# Altium schematic sheet sense2.SchDoc
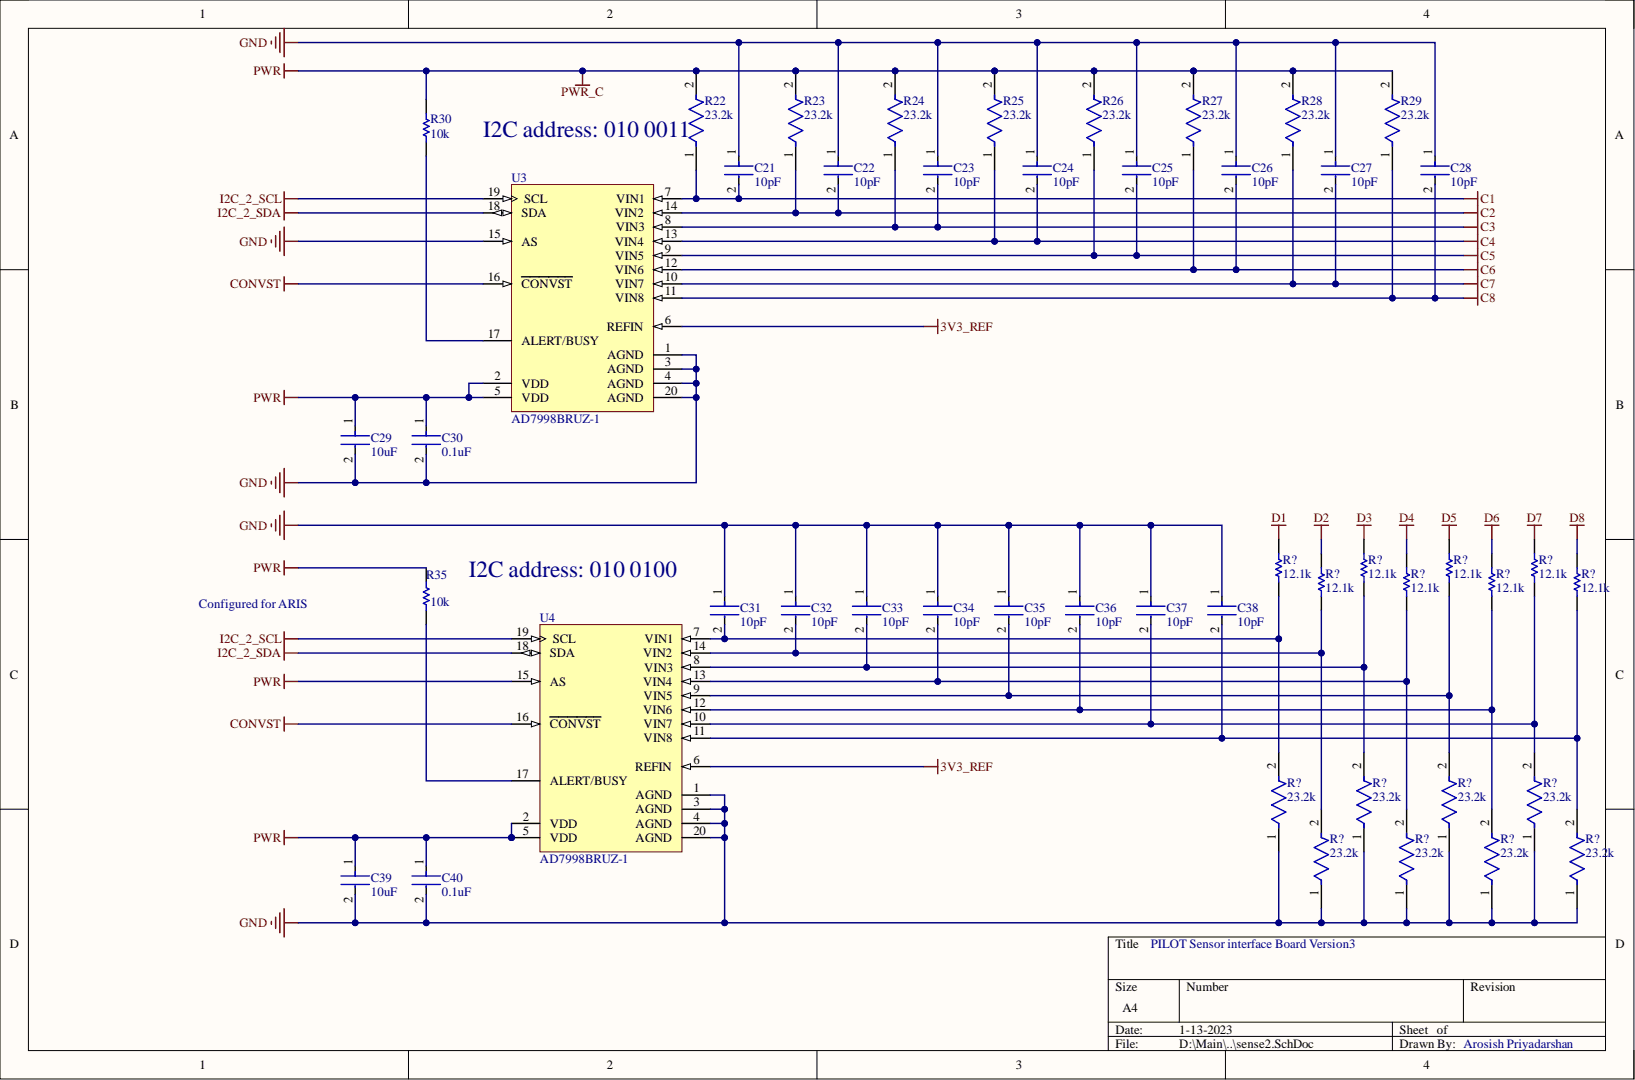
[diagram p0: sense2.SchDoc]

## Parts
C21  10pF  [FP-C0603C-CF-MFG]
C22  10pF  [FP-C0603C-CF-MFG]
C23  10pF  [FP-C0603C-CF-MFG]
C24  10pF  [FP-C0603C-CF-MFG]
C25  10pF  [FP-C0603C-CF-MFG]
C26  10pF  [FP-C0603C-CF-MFG]
C27  10pF  [FP-C0603C-CF-MFG]
C28  10pF  [FP-C0603C-CF-MFG]
C29  10uF  [FP-GCM21B-0_2-e0_2_0_7-IPC_C]
C30  0.1uF  [FP-C0603C104K5RECAUTO-MFG]
C31  10pF  [FP-C0603C-CF-MFG]
C32  10pF  [FP-C0603C-CF-MFG]
C33  10pF  [FP-C0603C-CF-MFG]
C34  10pF  [FP-C0603C-CF-MFG]
C35  10pF  [FP-C0603C-CF-MFG]
C36  10pF  [FP-C0603C-CF-MFG]
C37  10pF  [FP-C0603C-CF-MFG]
C38  10pF  [FP-C0603C-CF-MFG]
C39  10uF  [FP-GCM21B-0_2-e0_2_0_7-IPC_C]
C40  0.1uF  [FP-C0603C104K5RECAUTO-MFG]
R22  23.2k  [FP-RT0805-MFG]
R23  23.2k  [FP-RT0805-MFG]
R24  23.2k  [FP-RT0805-MFG]
R25  23.2k  [FP-RT0805-MFG]
R26  23.2k  [FP-RT0805-MFG]
R27  23.2k  [FP-RT0805-MFG]
R28  23.2k  [FP-RT0805-MFG]
R29  23.2k  [FP-RT0805-MFG]
R30  10k  [RESC2013X55X40ML15T20]
R35  10k  [RESC2013X55X40ML15T20]
R?  23.2k  [FP-RT0805-MFG]
R?  23.2k  [FP-RT0805-MFG]
R?  23.2k  [FP-RT0805-MFG]
R?  23.2k  [FP-RT0805-MFG]
R?  23.2k  [FP-RT0805-MFG]
R?  23.2k  [FP-RT0805-MFG]
R?  23.2k  [FP-RT0805-MFG]
R?  23.2k  [FP-RT0805-MFG]
R?  12.1k  [RESC2013X60X40LL20T25]
R?  12.1k  [RESC2013X60X40LL20T25]
R?  12.1k  [RESC2013X60X40LL20T25]
R?  12.1k  [RESC2013X60X40LL20T25]
R?  12.1k  [RESC2013X60X40LL20T25]
R?  12.1k  [RESC2013X60X40LL20T25]
R?  12.1k  [RESC2013X60X40LL20T25]
R?  12.1k  [RESC2013X60X40LL20T25]
U3  AD7998BRUZ-1  [RU-20_N]
U4  AD7998BRUZ-1  [RU-20_N]

## Nets
3V3_REF: U3.6(REFIN) U4.6(REFIN)
C1: C21.2 R22.1 U3.7(VIN1)
C2: C22.2 R23.1 U3.14(VIN2)
C3: C23.2 R24.1 U3.8(VIN3)
C4: C24.2 R25.1 U3.13(VIN4)
C5: C25.2 R26.1 U3.9(VIN5)
C6: C26.2 R27.1 U3.12(VIN6)
C7: C27.2 R28.1 U3.10(VIN7)
C8: C28.2 R29.1 U3.11(VIN8)
CONVST: U3.16(CONVST) U4.16(CONVST)
D1: R?.2
D2: R?.2
D3: R?.2
D4: R?.2
D5: R?.2
D6: R?.2
D7: R?.2
D8: R?.2
GND: C21.1 C22.1 C23.1 C24.1 C25.1 C26.1 C27.1 C28.1 C29.2 C30.2 C31.1 C32.1 C33.1 C34.1 C35.1 C36.1 C37.1 C38.1 C39.2 C40.2 R?.1 U3.1(AGND) U3.3(AGND) U3.4(AGND) U3.15(AS) U3.20(AGND) U4.1(AGND) U4.3(AGND) U4.4(AGND) U4.20(AGND)
I2C_2_SCL: U3.19(SCL) U4.19(SCL)
I2C_2_SDA: U3.18(SDA) U4.18(SDA)
PWR: C29.1 C30.1 C39.1 C40.1 R22.2 R23.2 R24.2 R25.2 R26.2 R27.2 R28.2 R29.2 R30.2 R35.2 U3.2(VDD) U3.5(VDD) U4.2(VDD) U4.5(VDD) U4.15(AS)
NetC31_2: C31.2 R?.1 R?.2 U4.7(VIN1)
NetC32_2: C32.2 R?.1 R?.2 U4.14(VIN2)
NetC33_2: C33.2 R?.1 R?.2 U4.8(VIN3)
NetC34_2: C34.2 R?.1 R?.2 U4.13(VIN4)
NetC35_2: C35.2 R?.1 R?.2 U4.9(VIN5)
NetC36_2: C36.2 R?.1 R?.2 U4.12(VIN6)
NetC37_2: C37.2 R?.1 R?.2 U4.10(VIN7)
NetC38_2: C38.2 R?.1 R?.2 U4.11(VIN8)
NetR30_1: R30.1 U3.17(ALERT/BUSY)
NetR35_1: R35.1 U4.17(ALERT/BUSY)

## Library data of the parts
C21-C28 C31-C38 = CMP-03020-008759-1: C0603 10 pF C0G 30ppm/°C 5.00% 25 V; Manufacturer: KEMET; Manufacturer Part Number: C0603C100J3GACAUTO; Automotive Grade: Grade 1; Material: Ceramic; Tolerance: 0.05; Voltage Rating: 25 V; Automotive: Yes; Capacitance: 10 pF; Category: Cap; Component Kind: Standard; ComponentLink2Description: Datasheet; ComponentLink2URL: https://api.kemet.com/component-edge/download/datasheet/C0603C100J5GACAUTO.pdf; Device Class L1: Passive Components; Device Class L2: Capacitors; Device Class L3: Ceramic Capacitors; Height: 0.87mm; Lead Free: Yes; Library Name: Altium Content Vault; Octopart: https://octopart.com/c0603c100j3gacauto-kemet-72007099; Package: C0603C-CF; RoHS: TRUE; Temperature: -55°C to +125°C; Temperature Characteristic: C0G; Temperature Coefficient: 30ppm/°C; Temperature Range High: +125°C; Temperature Range Low: -55°C; Value: 10 pF
C29 C39 = CMP-06035-029187-1: Ceramic Capacitor for Automotive 10uF ±10% 16VDC X7S 0805 Embossed T/R; Component Kind: Standard; Library Name: Altium Content Vault
C30 C40 = CMP-03020-034675-1: CAP CER 0.10UF 50V X7R 0603; Capacitance: 100nF; Interface: SPI; Number of A/D Converters: 1; Number of Bits: 16; Sampling Rate: 4000S/s; Tolerance: 10%; Case Code (Imperial): 0603; Case Code (Metric): 1608; Case/Package: 0603; Component Kind: Standard; Library Name: Altium Content Vault; Max Operating Temperature: 125°C; Min Operating Temperature: -55°C; Mount: Surface Mount; Packaging: Tape and Reel; Ratings: AEC-Q200; RoHS Compliant: Yes; Voltage Rating: 50V
R22-R29 R? R? R? R? R? R? R? R? = CMP-03412-003095-1: RES SMD 23.2K OHM 0.1% 1/8W 0805; Manufacturer: Yageo; Manufacturer Part Number: RT0805BRD0723K2L; Power Rating: 0.125W; Resistance: 23.2KΩ; Temperature Coefficient: 25 ppm/°C; Tolerance: 0.0025; Value: 23.2KΩ; Voltage Rating: 150V; Automotive: No; Category: Res; Component Kind: Standard; ComponentLink2Description: Datasheet; ComponentLink2URL: http://www.yageo.com/documents/recent/PYu-RT_1-to-0.01_RoHS_L_9.pdf; Composition: Thin Film; Device Class L1: Passive Components; Device Class L2: Resistors; Device Class L3: Chip SMD Resistors; Digikey Description: RES SMD 23.2K OHM 0.1% 1/8W 0805; Footprint Url: http://www.yageo.com/exep/pages/download/literatures/PYu-R_Mount_10.pdf; Height: 0.6mm; Ipc Land Pattern Name: RESC200125X50; Lead Free: Yes; Library Name: Altium Content Vault; Mouser Description: Thin Film Resistors - SMD 1/8W 23.2K ohm .1% 25ppm; Octopart: https://octopart.com/rt0805brd0723k2l-yageo-1375179; Package: RT0805; RoHS: TRUE; Series: RT; Temperature: -55°C to +155°C; Temperature Range High: +155°C; Temperature Range Low: -55°C
R30 R35 = CMP-2001-04724-1: Manufacturer: Vishay Dale; Part Number: TNPW080510K0BEEA; Component Kind: Standard; Library Name: Altium Content Vault
R? R? R? R? R? R? R? R? = CMP-2001-00729-1: Manufacturer: Panasonic; Part Number: ERA6AEB1212V; Component Kind: Standard; Library Name: Altium Content Vault
U3 U4 = CMP-0313-01824-2: Octal 12-Bit Analog-to-Digital Converter with I2C / Serial 2-Wire, Serialial Interface, 79KSPS, -40 to +85 degC, RU-20, Pb-Free, Tube; Generic Part Number: AD7998; Manufacturer: Analog Devices; Channels: 8; Interface: I2C/Ser 2-Wire, Ser; Resolution (Bits): 12; Throughput Rate (SPS): 79K; Code_JEDEC: MO-153AC; Component Kind: Standard; ComponentLink1Description: Manufacturer URL; ComponentLink1URL: http://www.analog.com/en/index.html; ComponentLink2Description: Datasheet; ComponentLink2URL: http://www.analog.com/static/imported-files/data_sheets/AD7997_7998.pdf; ComponentLink3Description: Package Specification; ComponentLink3URL: http://www.analog.com/static/imported-files/packages/364515793657813558433715854054607941441RU20.pdf; ComponentLink4Description: Product URL; ComponentLink4URL: http://www.analog.com/en/analog-to-digital-converters/ad-converters/ad7998/products/product.html; DatasheetVersion: Rev.0; Library Name: Altium Content Vault; Mounting Technology: Surface Mount; PackageDescription: 20-Lead Thin Shrink Small Outline Package [TSSOP]; PackageReference: RU-20; PackageVersion: 2000; Packing: Tube; PartNumber: AD7998BRUZ-1; RoHS: Y
